# Altium schematic sheet LineExtend.SchDoc
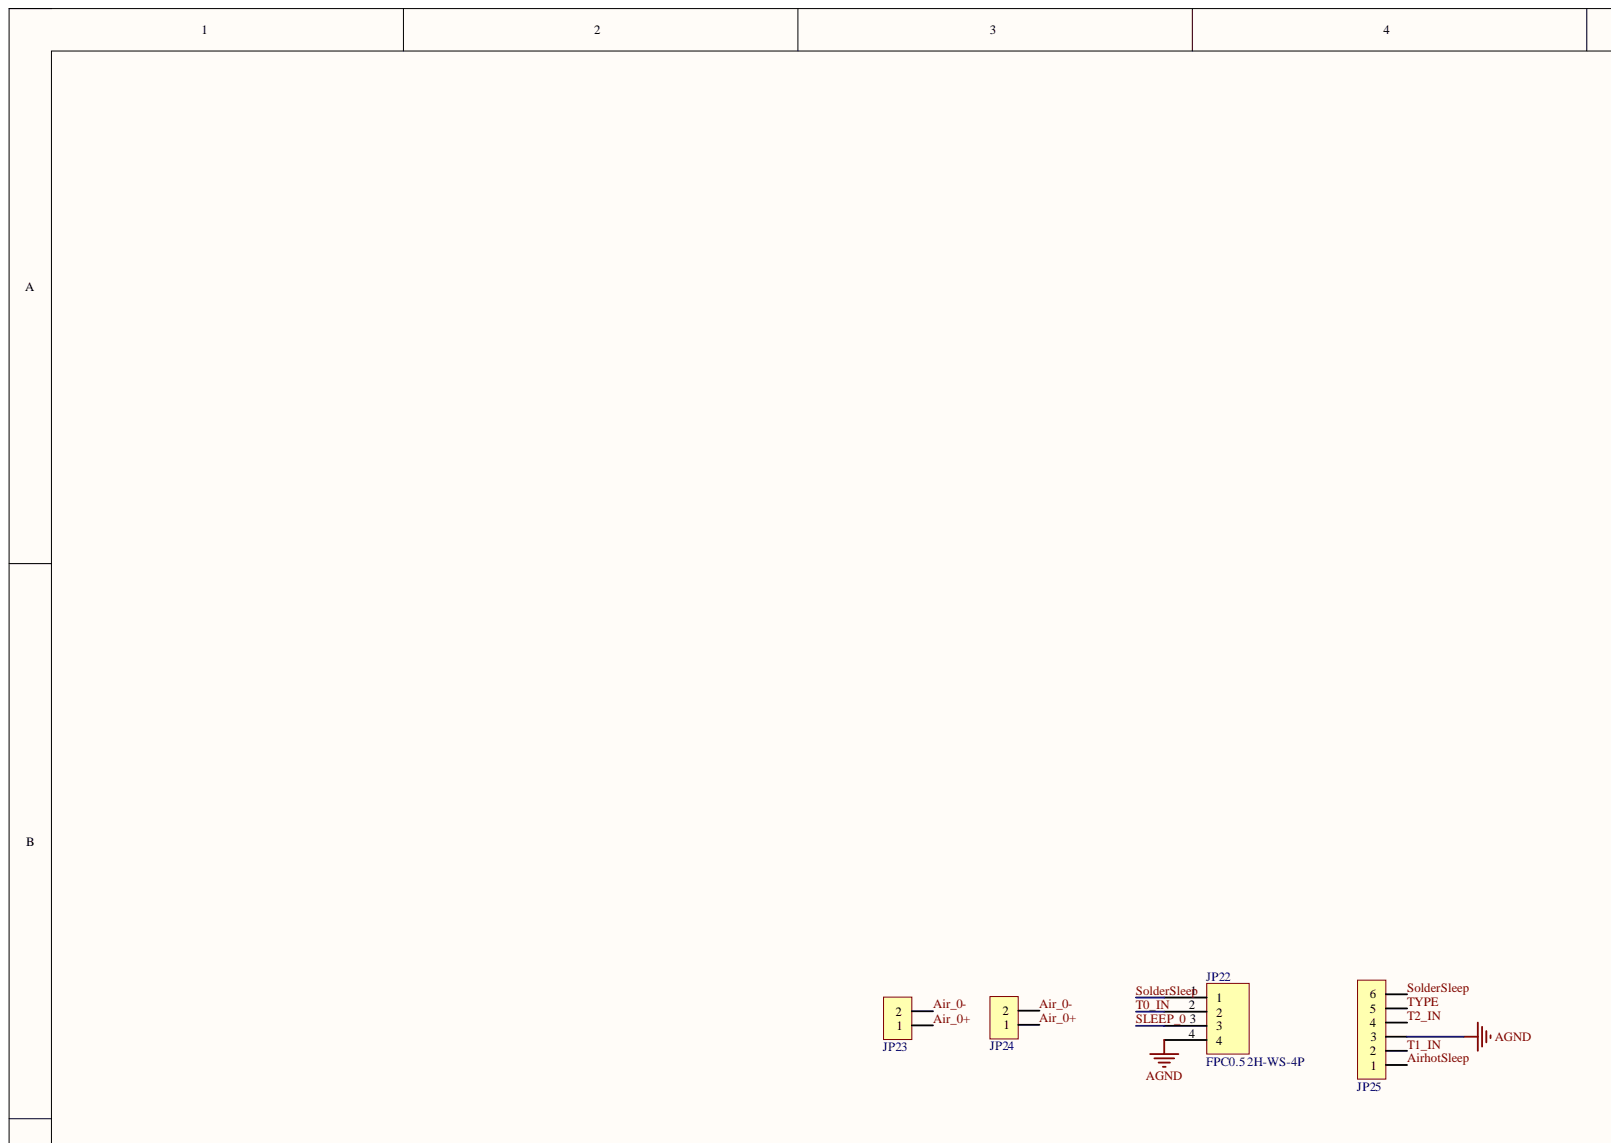
[diagram p0: LineExtend.SchDoc, top left]
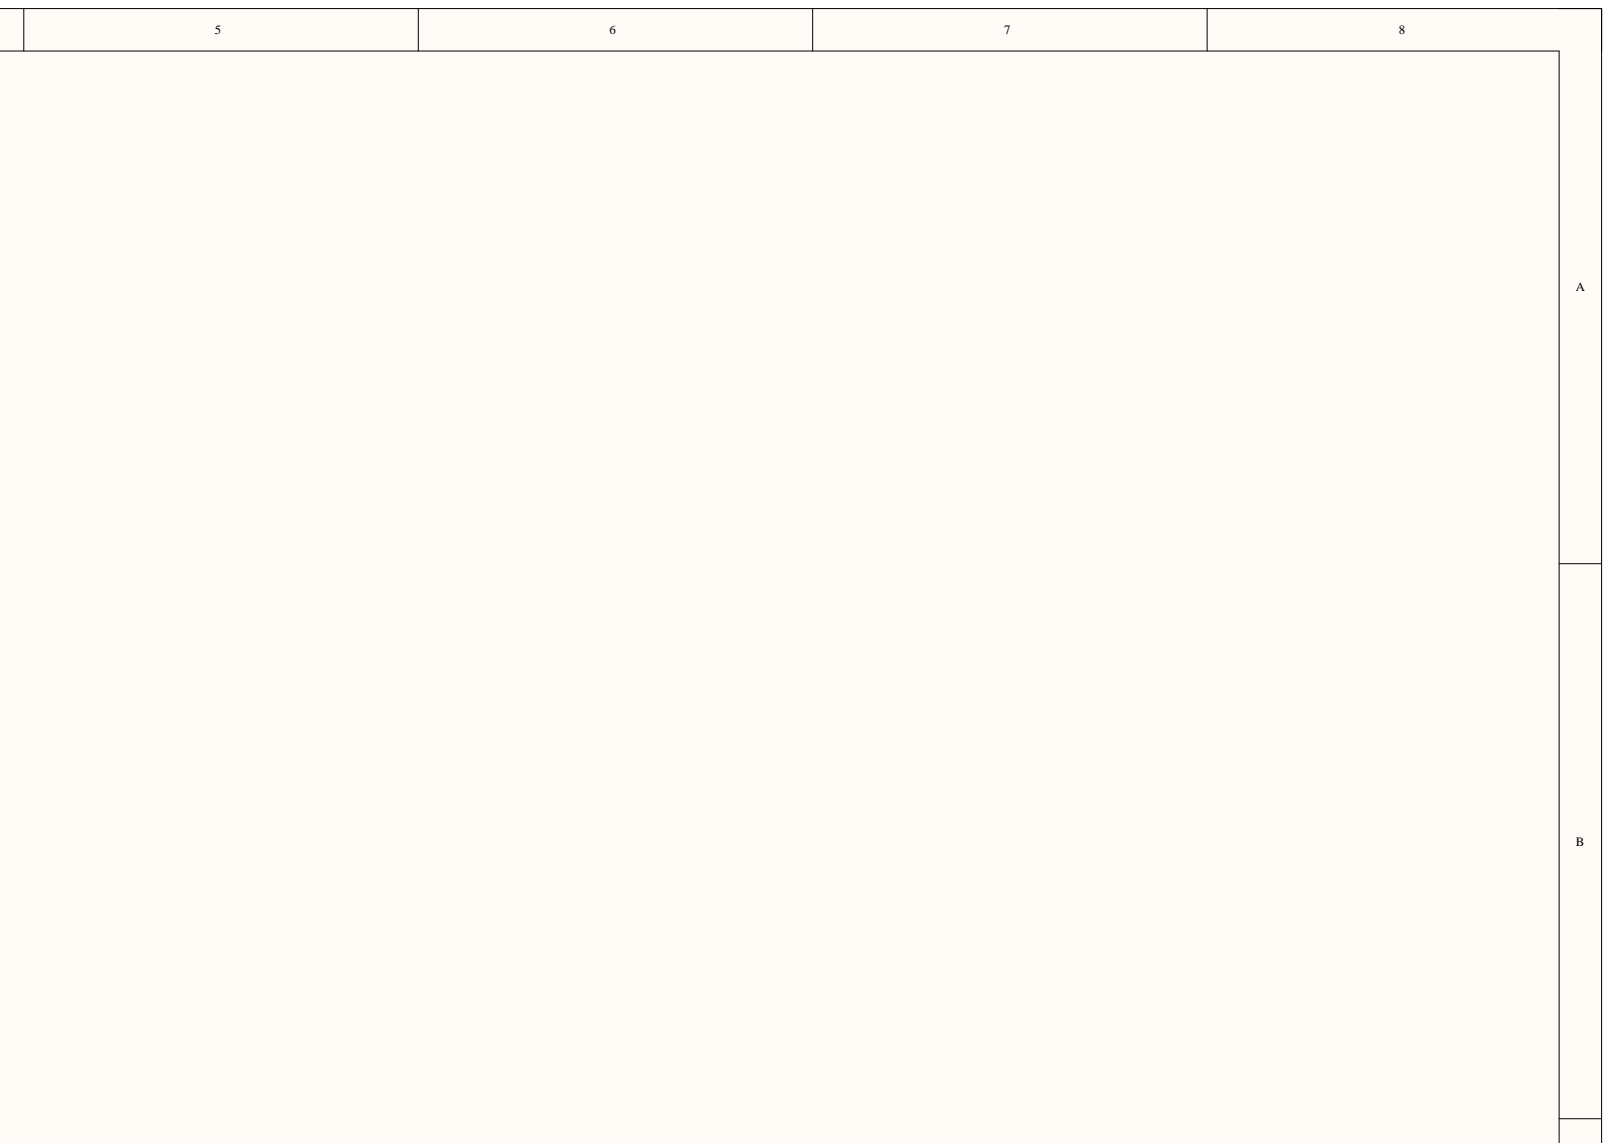
[diagram p1: LineExtend.SchDoc, top right]
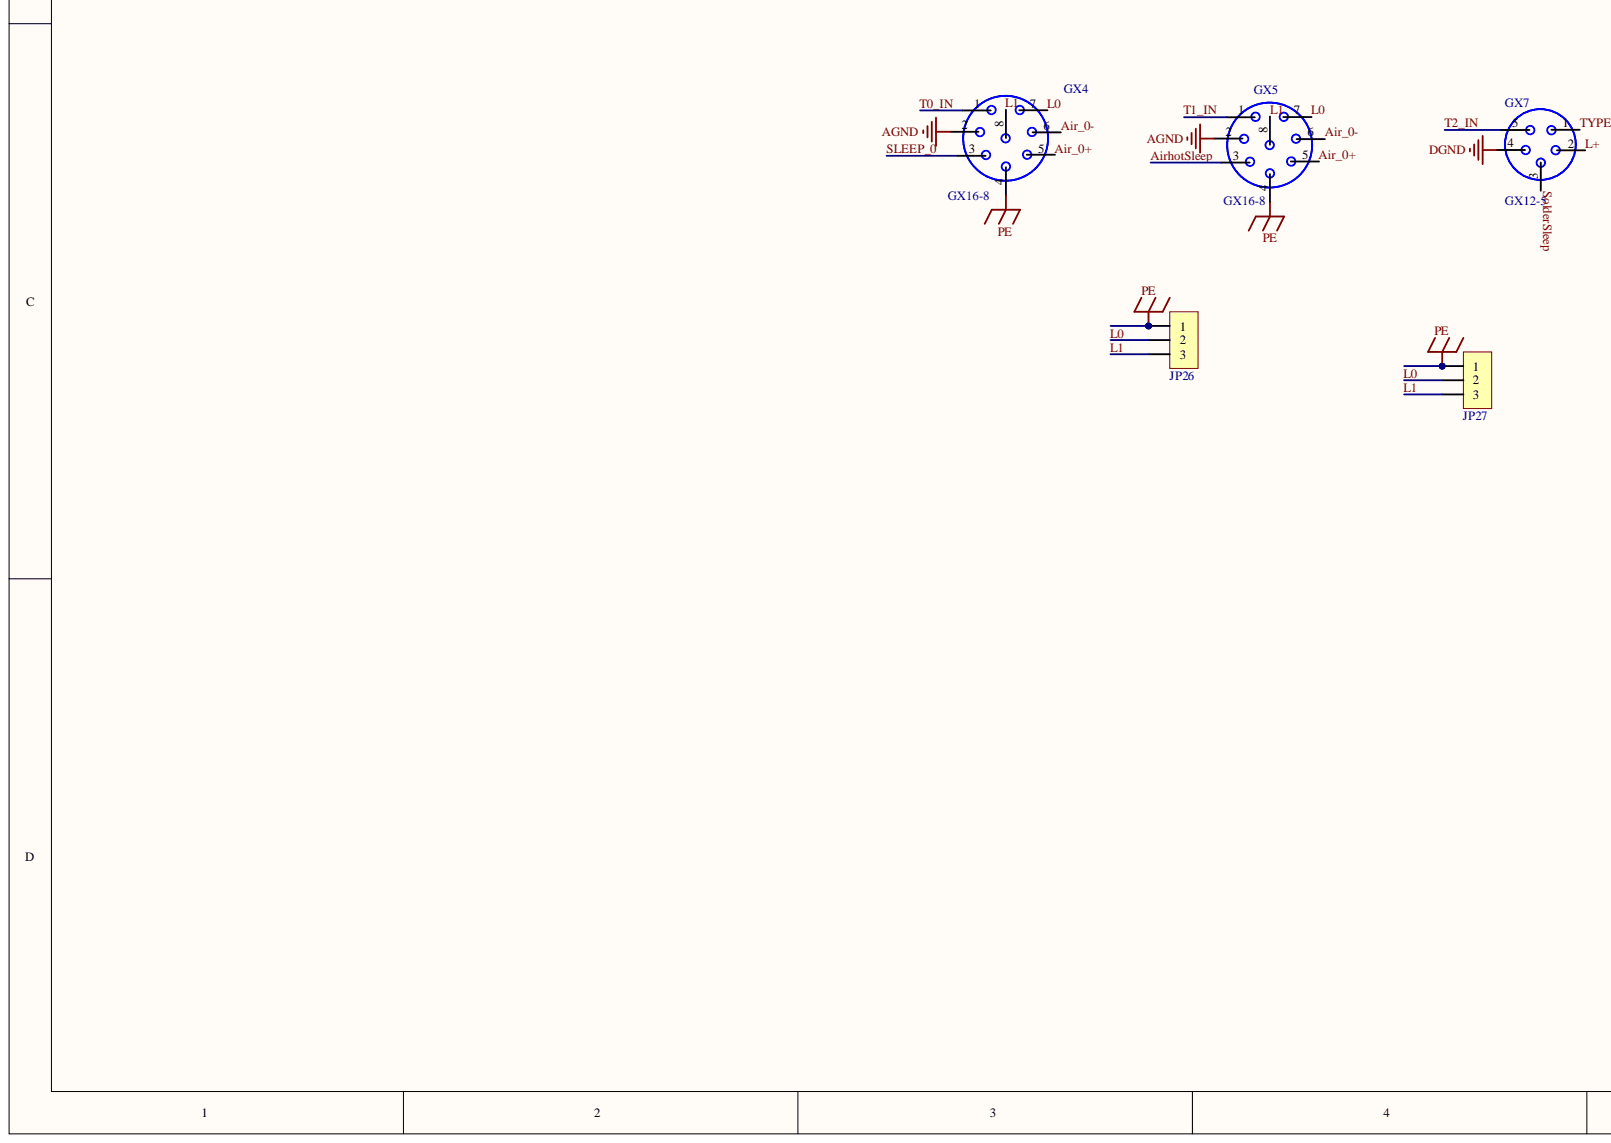
[diagram p2: LineExtend.SchDoc, bottom left]
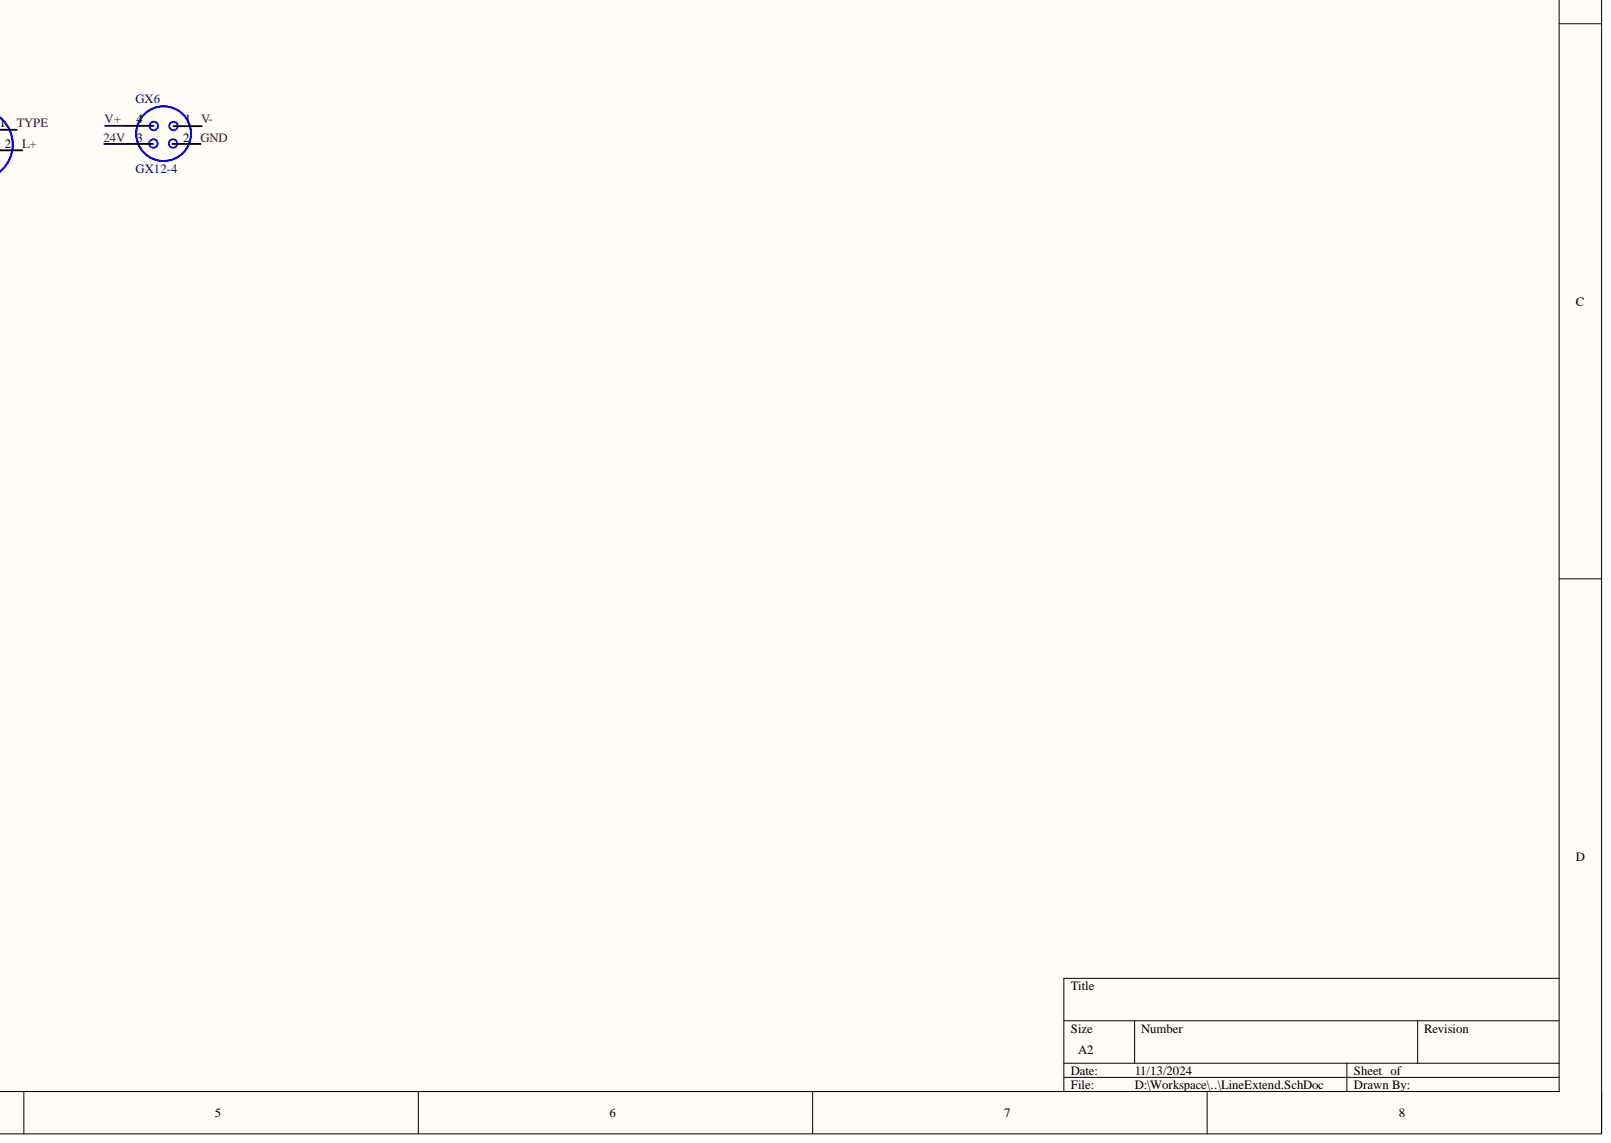
[diagram p3: LineExtend.SchDoc, bottom right]

## Parts
GX4  GX16-8  [GX16-8_hole]
GX5  GX16-8  [GX16-8_hole]
GX6  GX12-4  [GX12-2_hole]
GX7  GX12-5  [GX12-5_hole]
JP22  FPC0.5 2H-WS-4P  [FPC0.5 2H-WS-4P]
JP23  直插XH2.54  [XH2.54-LI-2P]  (value not drawn)
JP24  直插XH2.54  [XH2.54-LI-2P]  (value not drawn)
JP25  FPC0.5 2H-WS-6P  [FPC0.5 2H-WS-6P]  (value not drawn)
JP26  VH3.96_3P  [VH3.96-LI-3P]  (value not drawn)
JP27  VH3.96_3P  [VH3.96-LI-3P]  (value not drawn)

## Nets
AGND: GX4.2 GX5.2 JP22.4 JP25.3
DGND: GX7.4
PE: GX4.4 GX5.4 JP26.1 JP27.1
24V: GX6.3
Air_0+: GX4.5 GX5.5 JP23.1 JP24.1
Air_0-: GX4.6 GX5.6 JP23.2 JP24.2
AirhotSleep: GX5.3 JP25.1
GND: GX6.2
L0: GX4.7 GX5.7 JP26.2 JP27.2
L1: GX4.8 GX5.8 JP26.3 JP27.3
L+: GX7.2
SLEEP_0: GX4.3 JP22.3
SolderSleep: GX7.3 JP22.1 JP25.6
T0_IN: GX4.1 JP22.2
T1_IN: GX5.1 JP25.2
T2_IN: GX7.5 JP25.4
TYPE: GX7.1 JP25.5
V+: GX6.4
V-: GX6.1

## Library data of the parts
GX4 GX5 = GX-8: GX16-8航空头; BOM名称: 引线焊盘; Component Kind: Standard; Library Name: SuperHeat.SCHLIB
GX6 = GX-4: 4MM香蕉头一对; BOM名称: 引线焊盘; Component Kind: Standard; Library Name: SuperHeat.SCHLIB
GX7 = GX-5: GX12-5航空头; BOM名称: 引线焊盘; Component Kind: Standard; Library Name: SuperHeat.SCHLIB
JP22 = Header4: 4P接插件; Component Kind: Standard; Library Name: SuperHeat.SCHLIB
JP23 JP24 = Header 2: 2P接插件; 版权归属: 源创客; BOM名称: 2P接插件; Component Kind: Standard; 学习资源: www.ooocke.com; Library Name: SuperHeat.SCHLIB
JP25 = Header 6: 6P接插件; BOM名称: 6P接插件; Component Kind: Standard; Library Name: SuperHeat.SCHLIB
JP26 JP27 = Header 3: 3P接插件; 版权归属: 源创客; BOM名称: 3P接插件; Component Kind: Standard; 学习资源: www.ooocke.com; Library Name: SuperHeat.SCHLIB
